# Altium schematic sheet bph.SchDoc
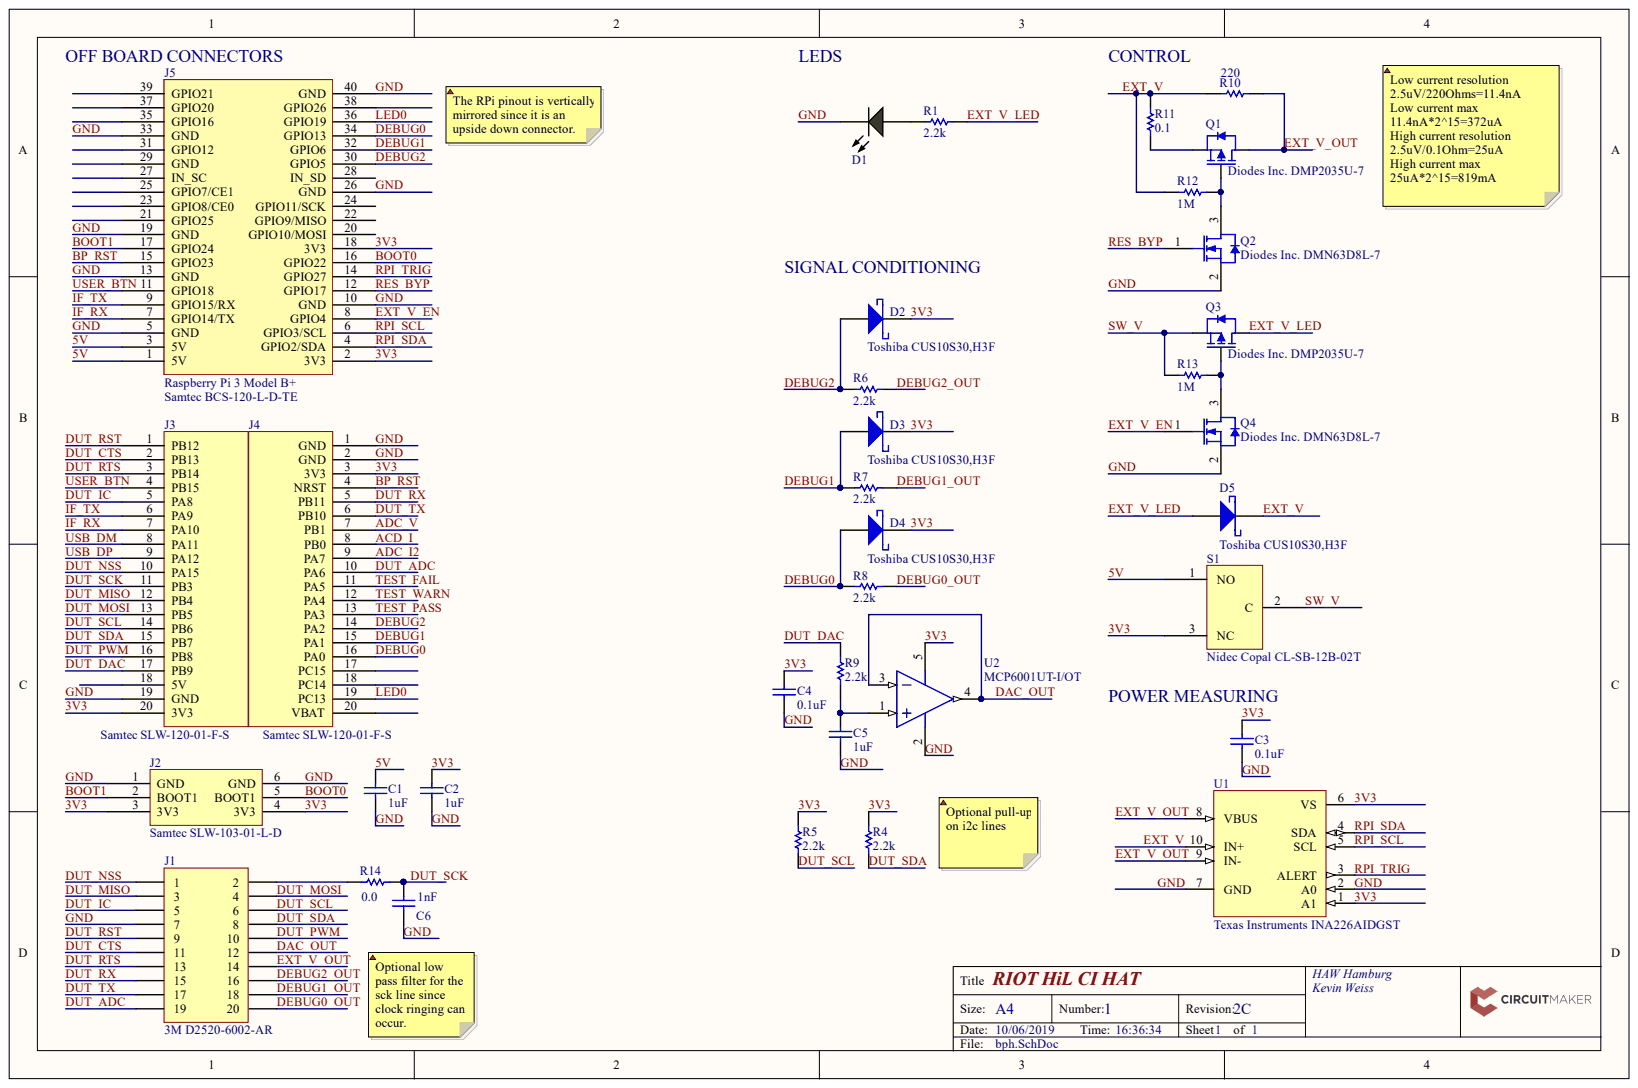
[diagram p0: bph.SchDoc]

## Parts
1  Raspberry Pi 3 Model B+  Manufacturer: Raspberry Pi  [PCB-50iu8tlf79vdd0w071gu-1]
2A  SYM-6f42xkpqtsuke1l2d5j8-1  [PCB-b7k0rssp4623vv64chpf-1]  (value not drawn)
2B  SYM-6f42xkpqtsuke1l2d5j8-1  [PCB-b7k0rssp4623vv64chpf-1]  (value not drawn)
2C  SYM-6f42xkpqtsuke1l2d5j8-1  [PCB-b7k0rssp4623vv64chpf-1]  (value not drawn)
C1  1uF  [CL10B105MO8NNWC]
C2  1uF  [CL10B105MO8NNWC]
C3  0.1uF  [CAPC1608X90X35LL15T15]
C4  0.1uF  [CAPC1608X90X35LL15T15]
C5  1uF  [CL10B105MO8NNWC]
C6  Capacitance: 1nF  [CAPC1608X92X38LL12T08]  (hidden comment: Vitramon VJ0603A102JNXAO)
D1  Vishay Semiconductor TLMG1100-GS08  [VSH-TLMX1100]  (value not drawn)
D2  Toshiba CUS10S30,H3F  Manufacturer Part Number: CUS10S30,H3F  [CUS10S30]
D3  Toshiba CUS10S30,H3F  Manufacturer Part Number: CUS10S30,H3F  [CUS10S30]
D4  Toshiba CUS10S30,H3F  Manufacturer Part Number: CUS10S30,H3F  [CUS10S30]
D5  Toshiba CUS10S30,H3F  Manufacturer Part Number: CUS10S30,H3F  [CUS10S30]
J1  3M D2520-6002-AR  Manufacturer: 3M  Manufacturer Part Number: D2520-6002-AR  [PCB-nfmrwd3jbcq44p7ypck8-1]
J2  Samtec SLW-103-01-L-D  Manufacturer Part Number: SLW-103-01-L-D  [PCB-u747vjd4cdo72hfoo1ax-1]
J3  Samtec SLW-120-01-F-S  Manufacturer Part Number: SLW-120-01-F-S  [PCB-8qynmsy03wmiyf28j7k7-1]
J4  Samtec SLW-120-01-F-S  Manufacturer Part Number: SLW-120-01-F-S  [PCB-8qynmsy03wmiyf28j7k7-1]
J5  Samtec BCS-120-L-D-TE  Manufacturer Part Number: BCS-120-L-D-TE  [PCB-novmi6c1j8vn69uaksfq-1]
Q1  Diodes Inc. DMP2035U-7  [ONSC-SOT-23-3-318-08_V]
Q2  Diodes Inc. DMN63D8L-7  [PCB-q6ucxelsfuo3l1kgupd0-1]
Q3  Diodes Inc. DMP2035U-7  [ONSC-SOT-23-3-318-08_V]
Q4  Diodes Inc. DMN63D8L-7  [PCB-q6ucxelsfuo3l1kgupd0-1]
R1  2.2k  [RESC1608X55X30LL15T15]
R4  2.2k  [RESC1608X55X30LL15T15]
R5  2.2k  [RESC1608X55X30LL15T15]
R6  2.2k  [RESC1608X55X30LL15T15]
R7  2.2k  [RESC1608X55X30LL15T15]
R8  2.2k  [RESC1608X55X30LL15T15]
R9  2.2k  [RESC1608X55X30LL15T15]
R10  Vishay Dale CRCW1210220RFKEA  [RESC3225X60X45NL20T20]  (value not drawn)
R11  Resistance: 0.1  [RESC3126X65X50ML10T20]  (hidden comment: Yageo RL1210FR-070R1L)
R12  1M  [RESC1608X55X30LL15T15]
R13  1M  [RESC1608X55X30LL15T15]
R14  Resistance: 0.0  [RESC1608X55X25LL10T15]  (hidden comment: Yageo AC0603JR-070RL)
S1  Nidec Copal CL-SB-12B-02T  Manufacturer Part Number: CL-SB-12B-02T  [PCB-3w9549xuhg7p8i6xstg2-1]
U1  Texas Instruments INA226AIDGST  Manufacturer: Texas Instruments  Manufacturer Part Number: INA226AIDGST  [PCB-TSSOP10-BoxOverlay]
U2  MCP6001UT-I/OT  [SOT-23-OT5_N]

## Nets
3V3: C2.2 C3.2 C4.2 D2.1 D3.1 D4.1 J2.3(3V3) J2.4(3V3) J3.20(3V3) J4.3(3V3) J5.2(3V3) J5.18(3V3) R4.1 R5.1 S1.3 U1.1(A1) U1.6(VS) U2.5
5V: C1.2 J5.1(5V) J5.3(5V) S1.1
ACD_I: J4.8(PB0)
ADC_I2: J4.9(PA7)
ADC_V: J4.7(PB1)
BOOT0: J2.5(BOOT1) J5.16(GPIO22)
BOOT1: J2.2(BOOT1) J5.17(GPIO24)
BP_RST: J4.4(NRST) J5.15(GPIO23)
DAC_OUT: J1.12 U2.3 U2.4
DEBUG0: D4.2 J4.16(PA0) J5.34(GPIO13) R8.2
DEBUG0_OUT: J1.20 R8.1
DEBUG1: D3.2 J4.15(PA1) J5.32(GPIO6) R7.2
DEBUG1_OUT: J1.18 R7.1
DEBUG2: D2.2 J4.14(PA2) J5.30(GPIO5) R6.2
DEBUG2_OUT: J1.16 R6.1
DUT_ADC: J1.19 J4.10(PA6)
DUT_CTS: J1.11 J3.2(PB13)
DUT_DAC: J3.17(PB9) R9.2
DUT_IC: J1.5 J3.5(PA8)
DUT_MISO: J1.3 J3.12(PB4)
DUT_MOSI: J1.4 J3.13(PB5)
DUT_NSS: J1.1 J3.10(PA15)
DUT_PWM: J1.10 J3.16(PB8)
DUT_RST: J1.9 J3.1(PB12)
DUT_RTS: J1.13 J3.3(PB14)
DUT_RX: J1.15 J4.5(PB11)
DUT_SCK: C6.2 J3.11(PB3) R14.2
DUT_SCL: J1.6 J3.14(PB6) R5.2
DUT_SDA: J1.8 J3.15(PB7) R4.2
DUT_TX: J1.17 J4.6(PB10)
EXT_V: D5.1 R10.1 R11.2 R12.2 U1.10(IN+)
EXT_V_EN: J5.8(GPIO4) Q4.1
EXT_V_LED: D5.2 Q3.3 R1.2
EXT_V_OUT: J1.14 Q1.3 R10.2 U1.8(VBUS) U1.9(IN-)
GND: C1.1 C2.1 C3.1 C4.1 C5.1 C6.1 D1.2 J1.7 J2.1(GND) J2.6(GND) J3.19(GND) J4.1(GND) J4.2(GND) J5.5(GND) J5.10(GND) J5.13(GND) J5.19(GND) J5.26(GND) J5.33(GND) J5.40(GND) Q2.2 Q4.2 U1.2(A0) U1.7(GND) U2.2
IF_RX: J3.7(PA10) J5.7(GPIO14/TX)
IF_TX: J3.6(PA9) J5.9(GPIO15/RX)
LED0: J4.19(PC13) J5.36(GPIO19)
RES_BYP: J5.12(GPIO17) Q2.1
RPI_SCL: J5.6(GPIO3/SCL) U1.5(SCL)
RPI_SDA: J5.4(GPIO2/SDA) U1.4(SDA)
RPI_TRIG: J5.14(GPIO27) U1.3(ALERT)
SW_V: Q3.2 R13.2 S1.2
TEST_FAIL: J4.11(PA5)
TEST_PASS: J4.13(PA3)
TEST_WARN: J4.12(PA4)
USB_DM: J3.8(PA11)
USB_DP: J3.9(PA12)
USER_BTN: J3.4(PB15) J5.11(GPIO18)
NetC5_2: C5.2 R9.1 U2.1
NetD1_1: D1.1 R1.1
NetJ1_2: J1.2 R14.1
NetQ1_1: Q1.1 Q2.3 R12.1
NetQ1_2: Q1.2 R11.1
NetQ3_1: Q3.1 Q4.3 R13.1

Not connected: J3 18(5V); J4 17(PC15) 18(PC14) 20(VBAT); J5 20(GPIO10/MOSI) 21(GPIO25) 22(GPIO9/MISO) 23(GPIO8/CE0) 24(GPIO11/SCK) 25(GPIO7/CE1) 27(IN_SC) 28(IN_SD) 29(GND) 31(GPIO12) 35(GPIO16) 37(GPIO20) 38(GPIO26) 39(GPIO21)

## Library data of the parts
1 = CMP-yq17slews1ccft8s9byl-1: 1.4GHz 64-bit quad-core processor, dual-band wireless LAN, Bluetooth 4.2/BLE, faster Ethernet; Component Kind: Standard; CustomComponentID: yq17slews1ccft8s9byl; Homepage URL: https://www.raspberrypi.org/products/raspberry-pi-3-model-b-plus/; Library Name: FavoritesLibrary; Manufacturer Part Number: Raspberry Pi 3 Model B+; Octopart URL: https://octopart.com/raspberry+pi+3+model+b%2B-raspberry+pi-90351262?r=sp&s=YfXGCw7vRHmoR15taoA5tA
2A 2B 2C = CMP-6f42xkpqtsuke1l2d5j8-3: Blue pill is the nickname given to the most popular, ultra-cheap and compact STM32F103 board; Manufacturer: Various; Manufacturer Part Number: STM32F103C8T6 Blue Pill; Component Kind: Standard; CustomComponentID: 6f42xkpqtsuke1l2d5j8; Homepage URL: https://wiki.stm32duino.com/index.php?title=Blue_Pill; Library Name: CommunityVault
C1 C2 C5 = CMP-8faa45df076d506d-3: CL10 Series 0603 16 V 1 uF ±20% Tolerance X7R Multilayer Ceramic Capacitor; Manufacturer: Samsung Electro-Mechanics; Capacitance: 1µF; Lead-Free Status: Lead Free; Component Kind: Standard; Digikey Part Number: 1276-6524-1-ND; Library Name: FavoritesLibrary; Manufacturer Part Number: CL10B105MO8NNWC; OctopartID: 8faa45df076d506d; Octopart URL: http://octopart.com/cl10b105mo8nnwc-samsung-58699169; RoHS: Compliant
C3 C4 = CMP-3553328-2: Multilayer Ceramic Capacitors MLCC - SMD/SMT 25volts 0.1uF 10% X7R; Manufacturer: AVX Corporation; Manufacturer Part Number: 06033C104KAT4A; Capacitance: 1e-07 F; Lead-Free Status: Lead Free; Voltage Rating (DC): 25.0 V; Capacitance Tolerance: ±10%; Case/Package: 0603; Component Kind: Standard; Dielectric Characteristic: X7R; Digikey Part Number: 478-7018-1-ND; Library Name: CommunityVault; Mounting Style: Surface Mount; Number of Pins: 2; OctopartID: 7950b0d96468a697; Octopart Url: https://octopart.com/06033c104kat4a-avx+interconnect+%2F+elco-8599646; Packaging: Cut Tape (CT); REACH SVHC Compliance: No SVHC; RoHS: Compliant
C6 = CMP-125126-5: CAP CER 1000PF 25V 5% NP0 0603; Dielectric Characteristic: C0G/NP0; Voltage Rating (DC): 25.0 V; Capacitance Tolerance: ±5%; Component Kind: Standard; Library Name: CommunityVault; Manufacturer Part Number: VJ0603A102JNXAO; OctopartID: add8667b69f1721f; Octopart Url: https://octopart.com/vj0603a102jnxao-vishay-44687695; Packaging: Cut Tape (CT); RoHS: Exempt
D1 = CMP-1544807-3: LED 0603 ULTRA BRIGHT GRN 35MCD; Color: Green; Forward Voltage: 2.1 V; Lead-Free Status: Lead Free; Luminous Intensity: 0.035 cd; Peak Wavelength: 5.72e-07 m; Power Dissipation: 0.09 W; Viewing Angle: 160 °; Voltage Rating (DC): 3.0 V; Component Kind: Standard; Library Name: CommunityVault; Manufacturer Part Number: TLMG1100-GS08; Mounting Style: Surface Mount; Number of Pins: 2; OctopartID: 4d7f86f09dd192b8; Octopart Url: https://octopart.com/tlmg1100-gs08-vishay-42333640; REACH SVHC Compliance: No SVHC; RoHS: Compliant; Wavelength: 5.72e-07 m
D2-D5 = CMP-ea3f2007903935f8-3: Diode Schottky 2-Pin SOD-323; Manufacturer: Toshiba Semiconductor and Storage; Capacitance @ Vr, F: 135pF @ 0V, 1MHz; Current - Average Rectified (Io): 1A; Current - Reverse Leakage @ Vr: 500µA @ 30V; Speed: Fast Recovery =< 500ns, > 200mA (Io); Voltage - DC Reverse (Vr) (Max): 30V; Voltage - Forward (Vf) (Max) @ If: 230mV @ 100mA; Component Kind: Standard; Data Sheet: http://www.semicon.toshiba.co.jp/info/docget.jsp?type=datasheet&lang=en&pid=CUS10S30; Digikey Part Number: CUS10S30H3FCT-ND; Diode Type: Schottky; Library Name: CommunityVault; OctopartID: ea3f2007903935f8; Octopart URL: http://octopart.com/cus10s30%2Ch3f-toshiba-53632864; Package / Case: SC-76, SOD-323
J1 = CMP-3c40f5ca31e7e387-2: Header; LowProfile; 4-Wall; 0.1Inch; HighTemp; Straight; SMT; 20Cnts; Gender: Male; Number of Contacts: 20; Color: Black; Component Kind: Standard; Contact Material: Brass; Contact Plating: Gold; Digikey Part Number: MHC20E-ND; Library Name: FavoritesLibrary; Mounting Style: Solder, Through Hole; OctopartID: 3c40f5ca31e7e387; Octopart URL: https://octopart.com/d2520-6002-ar-3m-8399041; Packaging: Bulk; RoHS: Compliant
J2 = CMP-73c0bbe09742ac07-2: Headers & Wire Housings .100 Tiger Buy Low Profile Socket Strip; Manufacturer: Samtec Inc.; Gender: Female; Lead-Free Status: Lead Free; Voltage Rating (AC): 400 V; Component Kind: Standard; Contact Material: Phosphor Bronze; Contact Plating: Gold; Digikey Part Number: SAM12856-ND; Library Name: FavoritesLibrary; Mounting Style: Solder; Number of Rows: 2; OctopartID: 73c0bbe09742ac07; Octopart URL: https://octopart.com/slw-103-01-l-d-samtec-19579364; Packaging: Bulk; RoHS: Compliant
J3 J4 = CMP-3cedf4f6ab5ce32a-4: .100" Tiger Buy™ Low Profile Socket Strip; Manufacturer: Samtec Inc.; Gender: Female; Lead-Free Status: Lead Free; Voltage Rating (AC): 400 V; Component Kind: Standard; Contact Material: Phosphor Bronze; Contact Plating: Gold; Digikey Part Number: SAM12857-ND; Library Name: CommunityVault; Mounting Style: Solder; OctopartID: 3cedf4f6ab5ce32a; Octopart URL: https://octopart.com/slw-120-01-f-s-samtec-17937111; RoHS: Compliant
J5 = CMP-a195689bf0d37e52-4: Conn Socket Strip SKT 40 POS 2.54mm Solder ST Thru-Hole Automotive Tube; Manufacturer: Samtec Inc.; Gender: Female; Lead-Free Status: Lead Free; Number of Contacts: 40; Schematic Desciption: 40 pin RPi connector; Color: Black; Component Kind: Standard; Contact Material: Phosphor Bronze; Contact Plating: Gold; Digikey Part Number: SAM1008-20-ND; Housing Color: Black; Housing Material: Plastic; Library Name: FavoritesLibrary; Mounting Style: Through Hole, Vertical; Number of Positions: 40; Number of Rows: 2; OctopartID: a195689bf0d37e52; Octopart URL: https://octopart.com/bcs-120-l-d-te-samtec-291872; Operating Temperature: 125 °C (max); Packaging: Tube; Pin Pitch: 2.54 mm; REACH SVHC Compliance: No SVHC; RoHS: Compliant; Size-Height: 7.37 mm; Size-Length: 50.8 mm; Size-Width: 5.08 mm
Q1 Q3 = CMP-be917695578c6156-4: DMP2035U Series 20 V 35 mOhm P-Channel Enhancement Mode Mosfet - SOT-23-3; Manufacturer: Diodes Incorporated; Lead-Free Status: Lead Free; Component Kind: Standard; Digikey Part Number: DMP2035U-7DICT-ND; Lifecycle Status: Active; Manufacturer Part Number: DMP2035U-7; OctopartID: be917695578c6156; Octopart URL: http://octopart.com/dmp2035u-7-diodes+inc.-17747067; REACH SVHC Compliance: No SVHC; RoHS: Compliant
  Q1: Library Name: CommunityVault
  Q3: Library Name: FavoritesLibrary
Q2 Q4 = CMP-fe8c347ff177214b-3: Mosfet Bvdss: 25v~30v Sot23 t&r 3k; Manufacturer: Diodes Incorporated; Component Kind: Standard; Digikey Part Number: DMN63D8L-7DICT-ND; Library Name: FavoritesLibrary; Lifecycle Status: Not Listed by Manufacturer; Manufacturer Part Number: DMN63D8L-7; OctopartID: fe8c347ff177214b; Octopart URL: http://octopart.com/dmn63d8l-7-diodes+inc.-66661021
R1 R4-R9 = CMP-3652689-3: Thick Film Resistors - SMD 0603 2.2Kohms 5% Tol; Manufacturer: Panasonic Electronic Components; Manufacturer Part Number: ERJ-3GEYJ222V; Detailed Description: 2.2 kOhms ±5% 0.1W, 1/10W Chip Resistor 0603 (1608 Metric) Automotive AEC-Q200 Thick Film; Power (Watts): 0.1W, 1/10W; Resistance: 2,2 kOhms; Component Kind: Standard; Digikey Part Number: P2.2KGCT-ND; Library Name: FavoritesLibrary; Octopart URL: https://octopart.com/erj-3geyj222v-panasonic-55422038?r=sp&s=8AUfvkAbQV--CMs3oG4dvg; Package / Case: 0603 (1608 Metric); Supplier Device Package: 0603; Tolerance: ±5%
R10 = CMP-1924621-2: Thick Film Resistors - SMD 1/2watt 220ohms 1%; Lead-Free Status: Lead Free; Power Rating: 0.5 W; Resistance: 220; Voltage Rating (DC): 200.0 V; Case/Package: 1210; Component Kind: Standard; Library Name: CommunityVault; Manufacturer Part Number: CRCW1210220RFKEA; Mounting Style: Surface Mount; Number of Pins: 2; OctopartID: 57c29c970f508805; Octopart Url: https://octopart.com/crcw1210220rfkea-vishay+dale-39812596; Resistance Tolerance: ±1%; RoHS: Compliant; Size-Height: 0.00055 m; Size-Length: 0.0032 m; Size-Width: 0.0025 m
R11 = CMP-1862342-2: RES SMD 0.1 OHM 1% 1/2W 1210; Case/Package: 1210; Component Kind: Standard; Library Name: CommunityVault; Manufacturer Part Number: RL1210FR-070R1L; Mounting Style: Surface Mount; OctopartID: adc865f99a30720f; Octopart Url: https://octopart.com/rl1210fr-070r1l-yageo-20648606; Resistance Tolerance: ±1%
R12 R13 = CMP-3656597-6: Thick Film Resistors - SMD 0603 1Mohms 5% Tol; Manufacturer: Panasonic Electronic Components; Manufacturer Part Number: ERJ-3GEYJ105V; Lead-Free Status: Lead Free; Power Rating: 0.1 W; Resistance: 1000000.0 ?; Voltage Rating (DC): 75.0 V; Case/Package: 0603; Component Kind: Standard; Digikey Part Number: P1.0MGCT-ND; Library Name: CommunityVault; Lifecycle Status: Not Listed by Manufacturer; Mounting Style: Surface Mount; OctopartID: 962a5c6d1f5449ed; Octopart Url: https://octopart.com/erj-3geyj105v-panasonic-55421976; Packaging: Cut Tape (CT); REACH SVHC Compliance: No SVHC; Resistance Tolerance: ±5%; RoHS: Compliant; Size-Height: 0.00045 m; Size-Length: 0.0016 m; Size-Width: 0.0008 m
R14 = CMP-1871823-2: RES SMD 0.0 OHM JUMPER 1/10W; Lead-Free Status: Lead Free; Resistance Tolerance: ±5%; Case/Package: 0603; Component Kind: Standard; Library Name: CommunityVault; Manufacturer Part Number: AC0603JR-070RL; Mounting Style: Surface Mount; OctopartID: beb976f8d2dd617e; Octopart Url: https://octopart.com/ac0603jr-070rl-yageo-21711106; RoHS: Compliant
S1 = CMP-073bcb2432bcd8fc-2: Switch Slide N.O./N.C. SPDT Top Slide 0.2A 12VDC Gull Wing SMD T/R; Manufacturer: Nidec Copal Electronics; Lead-Free Status: Lead Free; Component Kind: Standard; Contacts Type: SPDT; Digikey Part Number: 563-1319-1-ND; Library Name: CommunityVault; Mounting Style: Surface Mount; OctopartID: 073bcb2432bcd8fc; Octopart URL: https://octopart.com/cl-sb-12b-02t-nidec+copal-85266657; Orientation: Vertical; Packaging: Tape & Reel (TR); RoHS: Compliant
U1 = CMP-947c5c4360184bbb-13: 36-V, Bi-Directional, Ultra-High Accuracy, Low-/High-Side, I2C Out Current/Power Monitor w/ Alert 10-VSSOP -40 to 125; Component Kind: Standard; Digikey Part Number: 296-29100-1-ND; Library Name: CommunityVault; Octopart URL: https://octopart.com/ina226aidgst-texas+instruments-20304130?r=sp&s=5-Oqy-OESGqIFekITAPw-Q
U2 = CMP-1319527-5: 1 MHz, Low-Power Operational Amplifier, 5-Pin SOT-23, Industrial Temperature, Tape and Reel; Manufacturer: Microchip Technology; Bandwidth: 1000000.0 Hz; Input Offset Drift: 2e-06 V/K; Lead-Free Status: Lead Free; Number of Channels: 1; Slew Rate: 0.6 V/?s; Case/Package: SOT-23; Component Kind: Standard; Digikey Part Number: MCP6001UT-I/OTCT-ND; Gain Bandwidth Product: 1000000.0 Hz; Library Name: FavoritesLibrary; Lifecycle Status: Active; Manufacturer Part Number: MCP6001UT-I/OT; Mounting Style: Surface Mount; Number of Circuits: 1; Number of Pins: 5; OctopartID: 592c9020195386eb; Octopart Url: https://octopart.com/mcp6001ut-i%2Fot-microchip-419097; REACH SVHC Compliance: No SVHC; RoHS: Compliant
